# Altium schematic sheet 12V_Supply.SchDoc(12V_Supply)
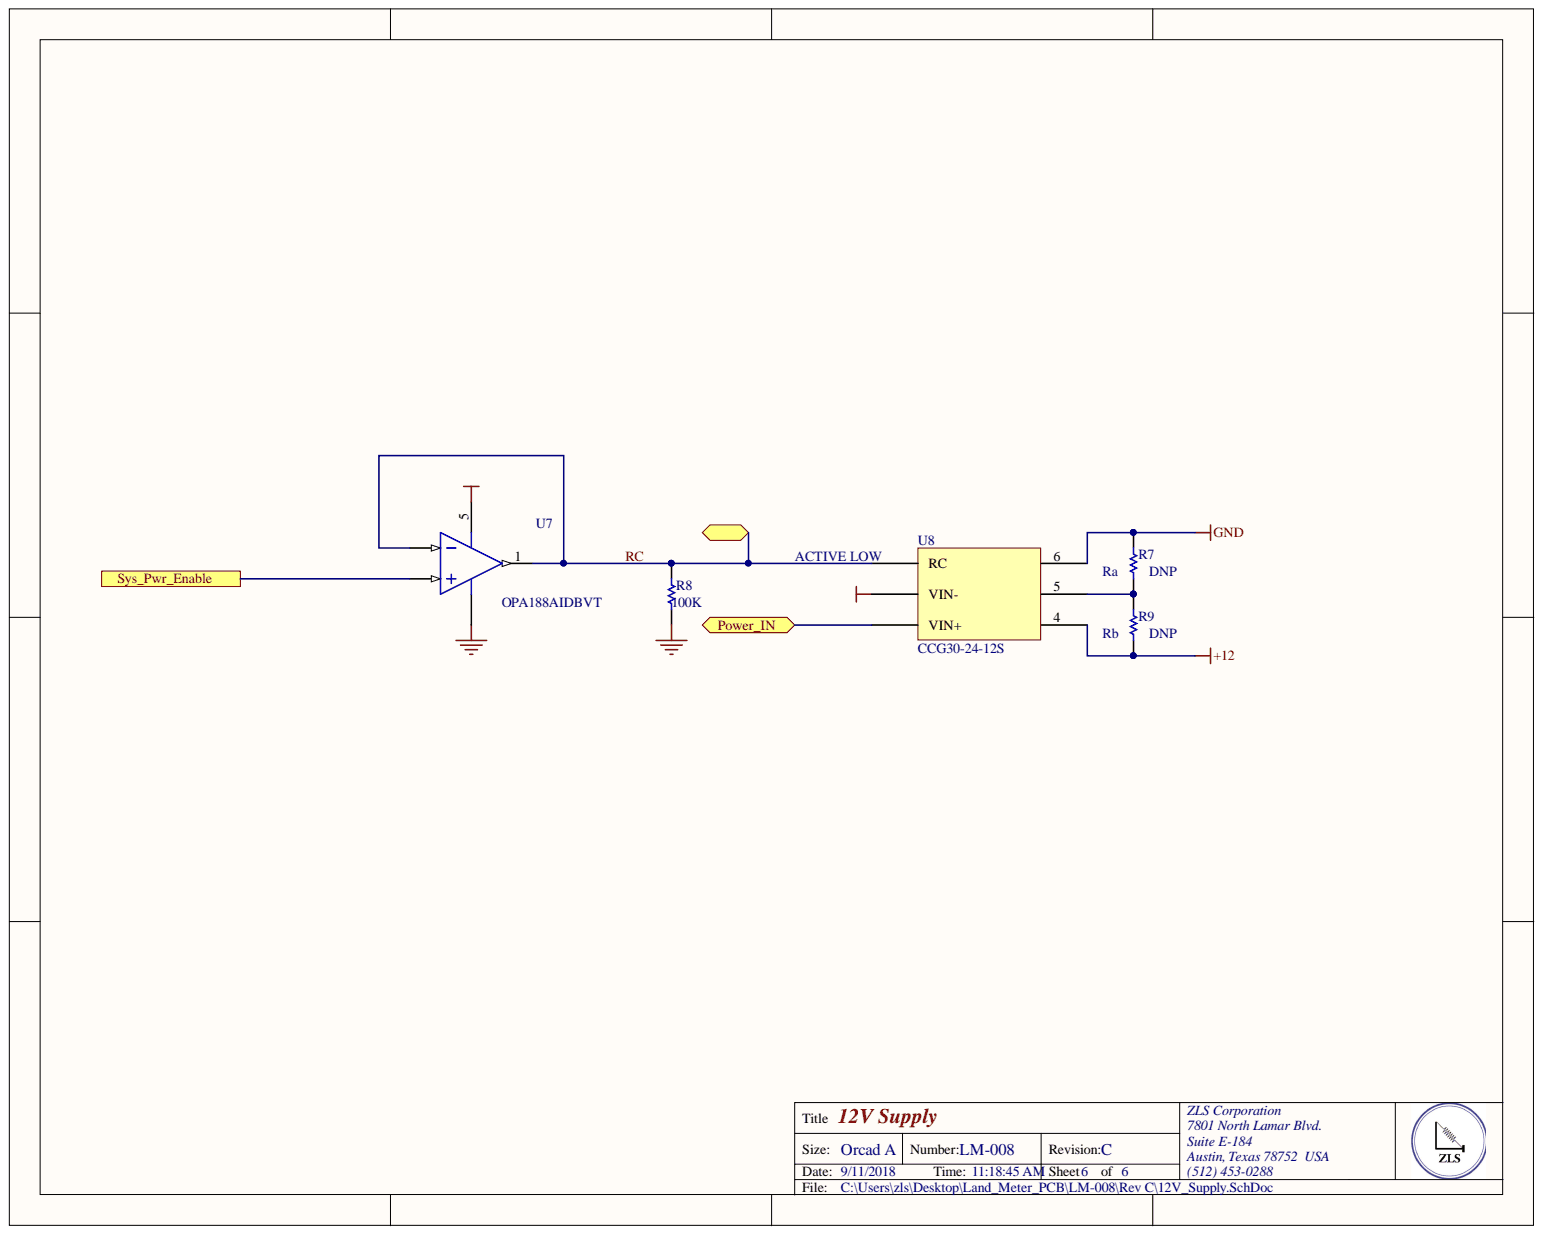
[diagram p0: 12V_Supply.SchDoc]

## Parts
R7  DNP  [RESC0805(2012)_M]
R8  Resistance: 100K  [RESC0805(2012)_M]  (hidden comment: RES SMD 100K OHM 1% 1/8W 0805)
R9  DNP  [RESC0805(2012)_M]
U7  OPA188AIDBVT  [DBV0005A_L]
U8  CCG30-24-12S  [PXB15-24WD05/N]

## Ports
Power_IN, RC, Sys_Pwr_Enable

## Nets
+5 BBB: U7.5
+12: R9.1 U8.4
GND: R7.2 R8.2 U7.2 U8.2(VIN-) U8.6
Power_IN: U8.3(VIN+) ; port Power_IN
RC: R8.1 U7.1 U7.4 U8.1(RC) ; port RC
Sys_Pwr_Enable: U7.3 ; port Sys_Pwr_Enable
NetR7_1: R7.1 R9.2 U8.5

## Library data of the parts
R7 = CMP-1013-00017-1: Vout = 12.05 ­ (445.7 / 63.1+Ra); Library Name: Altium Content Vault; Component Kind: Standard
R8 = CMP-1013-00017-1: Manufacturer Part Number: CRCW0805100KFKEA; Manufacturer: Vishay; Voltage Rating (DC): 150V; Temperature Coefficient: 100ppm/°C; Power Rating: 125mW; Width: 1.25mm; Weight: 0.000194oz; Voltage Rating: 150V; Tolerance: 1%; Termination: SMD/SMT; Supplier Part Number 1: CRCW0805100KFKEA/BKN; Supplier 1: Avnet; Stock 1: 1317; REACH SVHC: Unknown; Radiation Hardening: No; Pricing 1: 1=0.1, 10=0.049, 25=0.042, 50=0.035, 100=0.028 (USD); Packaging: Tape and Reel; Package Quantity: 5000; Number of Pins: 2; Mount: Surface Mount; Min Operating Temperature: -55°C; Military Standard: Not; Max Operating Temperature: 155°C; Library Name: Altium Content Vault; Length: 2mm; Lead Free: Lead Free; Height: 0.45mm; Description: RES SMD 100K OHM 1% 1/8W 0805; Depth: 1.25mm; Contact Plating: Tin; Composition: Thick Film; ComponentLink9URL: https://datasheet.ciiva.com/7777/1720486-7777565.pdf; ComponentLink9Description: https://datasheet.ciiva.com/7777/1720486-7777565.pdf; ComponentLink8URL: https://datasheet.ciiva.com/16720/70201119-16720891.pdf; ComponentLink8Description: https://datasheet.ciiva.com/16720/70201119-16720891.pdf; ComponentLink7URL: https://datasheet.ciiva.com/16852/dcrcwe3-16852810.pdf; ComponentLink7Description: https://datasheet.ciiva.com/16852/dcrcwe3-16852810.pdf; ComponentLink6URL: https://datasheet.ciiva.com/16341/dcrcwe3-16341530.pdf; ComponentLink6Description: https://datasheet.ciiva.com/16341/dcrcwe3-16341530.pdf; ComponentLink5URL: https://datasheet.ciiva.com/8337/dcrcwe3-8337738.pdf; ComponentLink5Description: https://datasheet.ciiva.com/8337/dcrcwe3-8337738.pdf; ComponentLink4URL: https://datasheet.ciiva.com/16754/70201119-16754822.pdf; ComponentLink4Description: https://datasheet.ciiva.com/16754/70201119-16754822.pdf; ComponentLink3URL: https://datasheet.ciiva.com/3258/dcrcwe3-3258006.pdf; ComponentLink3Description: https://datasheet.ciiva.com/3258/dcrcwe3-3258006.pdf; ComponentLink2URL: https://datasheet.ciiva.com/7777/1697337-7777349.pdf; ComponentLink2Description: https://datasheet.ciiva.com/7777/1697337-7777349.pdf; ComponentLink1URL: https://datasheet.ciiva.com/11809/0900766b80d98b26-11809057.pdf; ComponentLink1Description: https://datasheet.ciiva.com/11809/0900766b80d98b26-11809057.pdf; Component Kind: Standard; Case Code (Metric): 2012; Case Code (Imperial): 0805; Case/Package: 0805
R9 = CMP-1013-00017-1: Vout = 12.05 + (50.96 / 22+Rb); Library Name: Altium Content Vault; Component Kind: Standard
U7 = CMP-0809-00006-2: IC OPAMP ZERO-DRIFT 2MHZ SOT23-5; Manufacturer: Texas Instruments; Voltage - Supply, Single/Dual (±): 4 V ~ 36 V, ±2 V ~ 18 V; Slew Rate: 0.8 V/µs; Output Type: Rail-to-Rail; Current - Supply: 450µA; Supplier Part Number 1: 296-36218-1-ND; Supplier Device Package: SOT-23-5; Supplier 1: Digi-Key; Stock 1: 8627; RoHS: RoHS Compliant; Pricing 1: 1=2.83, 10=2.541, 100=2.0425 (USD); Part Status: Active; Packaging: Cut Tape (CT); Package / Case: SC-74A, SOT-753; Operating Temperature: -40°C ~ 125°C; Mounting Type: Surface Mount; Manufacturer Part Number: OPA188AIDBVT; Library Name: Altium Content Vault; ComponentLink1URL: http://www.ti.com/lit/ds/symlink/opa188.pdf; ComponentLink1Description: http://www.ti.com/lit/ds/symlink/opa188.pdf; Component Kind: Standard; Category: Integrated Circuits (ICs); Base Part Number: OPA188; Amplifier Type: Zero-Drift
U8 = CCG302412S: DC DC CONVERTER 12V 30W; Manufacturer: TDK-Lambda Americas Inc.; Voltage - Input (Min): 9V; Voltage - Input (Max): 36V; Efficiency: 89%; Current - Output (Max): 2.5A; Control Features: Enable, Active Low; Voltage - Output 3: -; Voltage - Output 2: -; Voltage - Output 1: 12V; Type: Isolated Module; Supplier Part Number 1: 285-2419-ND; Supplier 1: Digi-Key; Stock 1: 269; Size / Dimension: 1.00" L x 1.00" W x 0.39" H (25.4mm x 25.4mm x 9.9mm); RoHS: RoHS Compliant; Pricing 1: 1=41.1, 10=40.25 (USD); Part Status: Active; Packaging: Tray; Package / Case: 6-DIP Module; Operating Temperature: -40°C ~ 85°C; Mounting Type: Through Hole; Manufacturer Part Number: CCG302412S; Features: Remote On/Off, OCP, OVP; ComponentLink1URL: http://www.us.tdk-lambda.com/ftp/specs/ccg30.pdf; ComponentLink1Description: http://www.us.tdk-lambda.com/ftp/specs/ccg30.pdf; Component Kind: Standard; Category: Power Supplies - Board Mount; Applications: ITE (Commercial); Library Name: ZLS_Schematic_lib.SchLib
